# Altium schematic sheet Zlacza.SchDoc
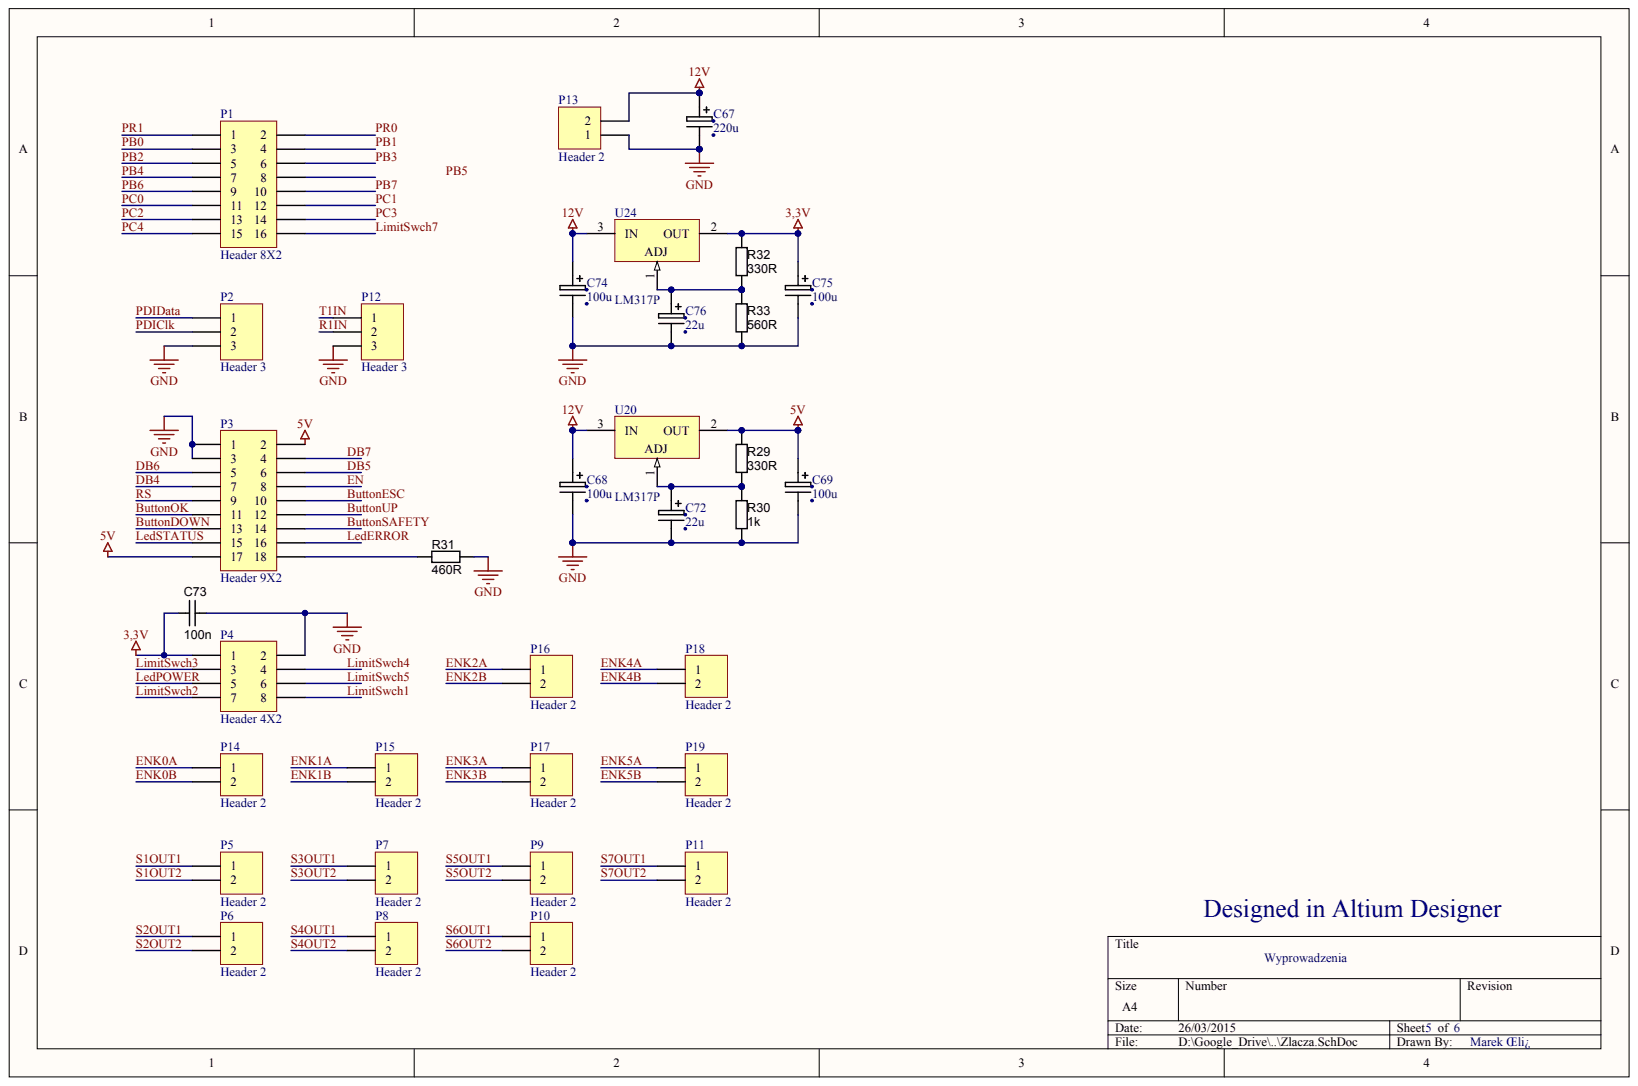
[diagram p0: Zlacza.SchDoc]

## Parts
C67  220u
C68  100u
C69  100u
C72  22u
C73  value 100n  (hidden comment: approved sub OK)
C74  100u
C75  100u
C76  22u
P1  Header 8X2
P2  Header 3
P3  Header 9X2
P4  Header 4X2
P5  Header 2
P6  Header 2
P7  Header 2
P8  Header 2
P9  Header 2
P10  Header 2
P11  Header 2
P12  Header 3
P13  Header 2
P14  Header 2
P15  Header 2
P16  Header 2
P17  Header 2
P18  Header 2
P19  Header 2
R29  value 330R  (hidden comment: RES_NL_0805)
R30  value 1k  (hidden comment: RES_NL_0805)
R31  value 460R  (hidden comment: RES_NL_0805)
R32  value 330R  (hidden comment: RES_NL_0805)
R33  value 560R  (hidden comment: RES_NL_0805)
U20  LM317P
U24  LM317P

## Nets
3,3V: C73.1 C75.1 P4.1 R32.2 U24.2
5V: C69.1 P3.2 P3.17 R29.1 U20.2
12V: C67.1 C68.1 C74.1 P13.2 U20.3 U24.3
GND: C67.2 C68.2 C69.2 C72.2 C73.2 C74.2 C75.2 C76.2 P2.3 P3.1 P3.3 P4.2 P12.3 P13.1 R30.2 R31.1 R33.2
BUTTONDOWN: P3.13
BUTTONESC: P3.10
BUTTONOK: P3.11
BUTTONSAFETY: P3.14
BUTTONUP: P3.12
DB4: P3.7
DB5: P3.6
DB6: P3.5
DB7: P3.4
EN: P3.8
ENK0A: P14.1
ENK0B: P14.2
ENK1A: P15.1
ENK1B: P15.2
ENK2A: P16.1
ENK2B: P16.2
ENK3A: P17.1
ENK3B: P17.2
ENK4A: P18.1
ENK4B: P18.2
ENK5A: P19.1
ENK5B: P19.2
LEDERROR: P3.16
LEDPOWER: P4.5
LEDSTATUS: P3.15
LIMITSWCH1: P4.8
LIMITSWCH2: P4.7
LIMITSWCH3: P4.3
LIMITSWCH4: P4.4
LIMITSWCH5: P4.6
LIMITSWCH7: P1.16
PB0: P1.3
PB1: P1.4
PB2: P1.5
PB3: P1.6
PB4: P1.7
PB6: P1.9
PB7: P1.10
PC0: P1.11
PC1: P1.12
PC2: P1.13
PC3: P1.14
PC4: P1.15
PDICLK: P2.2
PDIDATA: P2.1
PR0: P1.2
PR1: P1.1
R1IN: P12.2
RS: P3.9
S1OUT1: P5.1
S1OUT2: P5.2
S2OUT1: P6.1
S2OUT2: P6.2
S3OUT1: P7.1
S3OUT2: P7.2
S4OUT1: P8.1
S4OUT2: P8.2
S5OUT1: P9.1
S5OUT2: P9.2
S6OUT1: P10.1
S6OUT2: P10.2
S7OUT1: P11.1
S7OUT2: P11.2
T1IN: P12.1
NetC72_1: C72.1 R29.2 R30.1 U20.1
NetC76_1: C76.1 R32.1 R33.1 U24.1
NetP3_18: P3.18 R31.2
PB5:

Not connected: P1 8

## Library data of the parts
C73 = (no symbol name): Manufacturer 1: Kemet; Manufacturer Part Number 1: C0805C104K5RACTU; Tolerance: ±10%; Ratings: 50V; X7R; Material: ceramic; Package / Case: 0805 (2012 metric); Supplier 1: Digi-Key; Supplier Part Number 1: 399-1170-1-ND
R29-R33 = (no symbol name): Manufacturer 1: Royal Ohm; Ratings: 1/10 W; Tolerance: ±5%; Package / Case: 0805 (2012 metric)
C67-C69 C72 C74-C76 P1-P19 U20 U24 = (no symbol name)
  C67: Value: 220u
  C68 C69 C74 C75: Value: 100u
  C72 C76: Value: 22u
  P1-P19: LatestRevisionDate: 17-Jul-2002; LatestRevisionNote: Re-released for DXP Platform.; Publisher: Altium Limited
  U20 U24: DatasheetVersion: Nov-2011; PackageVersion: 2011; PackageReference: TO220FP; PackageDescription: TO, Thru-Hole, Vertical, Heatsink Mounted; 3 In-Line Leads; Pitch 2.54 mm; PartNumber: LM317P; ComponentLink1Description: Manufacturer URL; ComponentLink1URL: http://www.st.com; ComponentLink2Description: Datasheet; ComponentLink2URL: http://www.st.com/internet/com/TECHNICAL_RESOURCES/TECHNICAL_LITERATURE/DATASHEET/CD00000455.pdf
